# Altium schematic sheet MCU.SchDoc
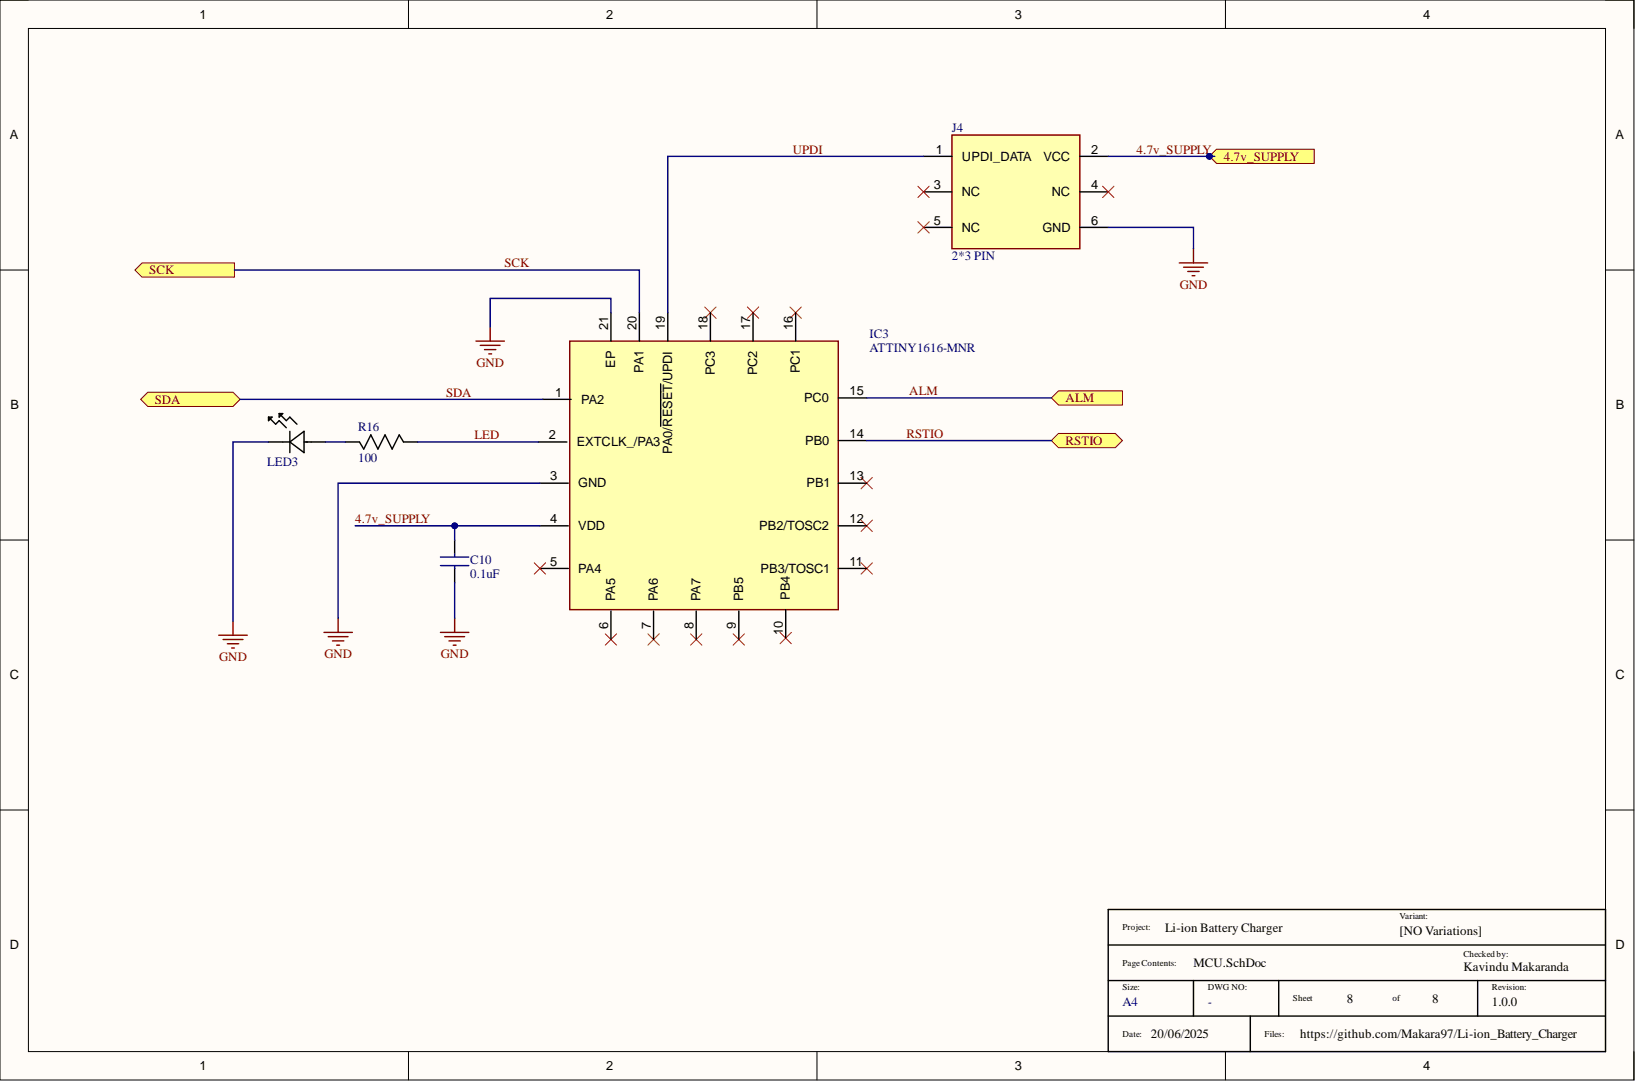
[diagram p0: MCU.SchDoc]

## Parts
C10  0.1uF  [C0603]
IC3  ATTINY1616-MNR  [QFN40P300X300X90-21N]
J4  2*3 PIN  [HDRV6W64P254_2X3_762X508X868P]
LED3  LED GREEN DIFFUSED 0603 SMD  [LED_B1931NG_HVK]  (value not drawn)
R16  100  [RESC1608X55N]

## Ports
4.7v_SUPPLY, ALM, RSTIO, SCK, SDA

## Nets
GND: C10.1 IC3.3(GND) IC3.21(EP) J4.6(GND) LED3.1
4.7v_SUPPLY: C10.2 IC3.4(VDD) J4.2(VCC) ; port 4.7v_SUPPLY
ALM: IC3.15(PC0) ; port ALM
LED: IC3.2(EXTCLK_/PA3) R16.1
RSTIO: IC3.14(PB0) ; port RSTIO
SCK: IC3.20(PA1) ; port SCK
SDA: IC3.1(PA2) ; port SDA
UPDI: IC3.19(PA0/RESET/UPDI) J4.1(UPDI_DATA)
NetIC3_5: IC3.5(PA4)
NetIC3_6: IC3.6(PA5)
NetIC3_7: IC3.7(PA6)
NetIC3_8: IC3.8(PA7)
NetIC3_9: IC3.9(PB5)
NetIC3_10: IC3.10(PB4)
NetIC3_11: IC3.11(PB3/TOSC1)
NetIC3_12: IC3.12(PB2/TOSC2)
NetIC3_13: IC3.13(PB1)
NetIC3_16: IC3.16(PC1)
NetIC3_17: IC3.17(PC2)
NetIC3_18: IC3.18(PC3)
NetJ4_3: J4.3(NC)
NetJ4_4: J4.4(NC)
NetJ4_5: J4.5(NC)
NetLED3_2: LED3.2 R16.2

## Library data of the parts
C10 = C0603C104K3RACTU: Capacitor C0603C104K3RACTU; Manufacturer_Name: KEMET; Arrow Part Number: C0603C104K3RACTU; Arrow Price/Stock: https://www.arrow.com/en/products/c0603c104k3ractu/kemet-corporation?utm_currency=USD&region=nac; Component Kind: Standard; Datasheet Link: https://content.kemet.com/datasheets/KEM_C1002_X7R_SMD.pdf; Height: 0.87mm; Library Name: SamacSys.SchLib; Manufacturer_Part_Number: C0603C104K3RACTU; Mouser Part Number: 80-C0603C104K3R; Mouser Price/Stock: https://www.mouser.co.uk/ProductDetail/KEMET/C0603C104K3RACTU?qs=l5k%252BbMnNDklvfdneglCDAg%3D%3D; Supplier 1: Arrow Electronics; Supplier Part Number 1: C0603C104K3RACTU
IC3 = ATTINY1616-MNR: Integrated Circuit ATTINY1616-MNR; Manufacturer_Name: Microchip; Arrow Part Number: ATTINY1616-MNR; Arrow Price/Stock: https://www.arrow.com/en/products/attiny1616-mnr/microchip-technology?utm_currency=USD&region=nac; Component Kind: Standard; Datasheet Link: https://datasheet.datasheetarchive.com/originals/distributors/DKDS41/DSANUWW0026757.pdf; Height: 0.9mm; Library Name: SamacSys.SchLib; Manufacturer_Part_Number: ATTINY1616-MNR; Mouser Part Number: 556-ATTINY1616MNR; Mouser Price/Stock: https://www.mouser.co.uk/ProductDetail/Microchip-Technology/ATTINY1616-MNR?qs=HXFqYaX1Q2yKNpuKQU9qww%3D%3D; Supplier 1: Digikey; Supplier Part Number 1: ATTINY1616-MNRCT-ND
J4 = M20-9980346: Connector; Manufacturer_Name: Harwin; Component Kind: Standard; Datasheet Link: https://cdn.harwin.com/pdfs/M20-998.pdf; Height: 8.68mm; Library Name: SamacSys.SchLib; Manufacturer_Part_Number: M20-9980346; Mouser Part Number: 855-M20-9980346; Mouser Price/Stock: https://www.mouser.co.uk/ProductDetail/Harwin/M20-9980346?qs=%252Bk6%2F5FB6qrmHk2P20oz3FA%3D%3D; Supplier 1: Digikey; Supplier Part Number 1: 952-2121-ND
LED3 = B1931NG--20D001114U1930: Mfr_Name: Harvatek; Type: LED Indication; Component Kind: Standard; Description: LED GREEN DIFFUSED 0603 SMD; Library Name: B1931NG--20D001114U1930.IntLib; Supplier 1: Digikey; Supplier Part Number 1: 3147-B1931NG--20D001114U1930CT-ND
R16 = ERJ-3EKF1000V: Resistor ERJ-3EKF1000V; Manufacturer_Name: Panasonic; Arrow Part Number: ERJ-3EKF1000V; Arrow Price/Stock: https://www.arrow.com/en/products/erj-3ekf1000v/panasonic?utm_currency=USD&region=nac; Component Kind: Standard; Datasheet Link: http://industrial.panasonic.com/cdbs/www-data/pdf/RDA0000/AOA0000C86.pdf; Height: 0.55mm; Library Name: SamacSys.SchLib; Manufacturer_Part_Number: ERJ-3EKF1000V; Mouser Part Number: 667-ERJ-3EKF1000V; Mouser Price/Stock: https://www.mouser.co.uk/ProductDetail/Panasonic/ERJ-3EKF1000V?qs=H7k1u0Mp9JS7i8KGO5m47A%3D%3D; Supplier 1: Mouser; Supplier Part Number 1: 667-ERJ-3EKF1000V
